# Altium schematic sheet IMX7S_CPU_INTERFACES_1.SchDoc
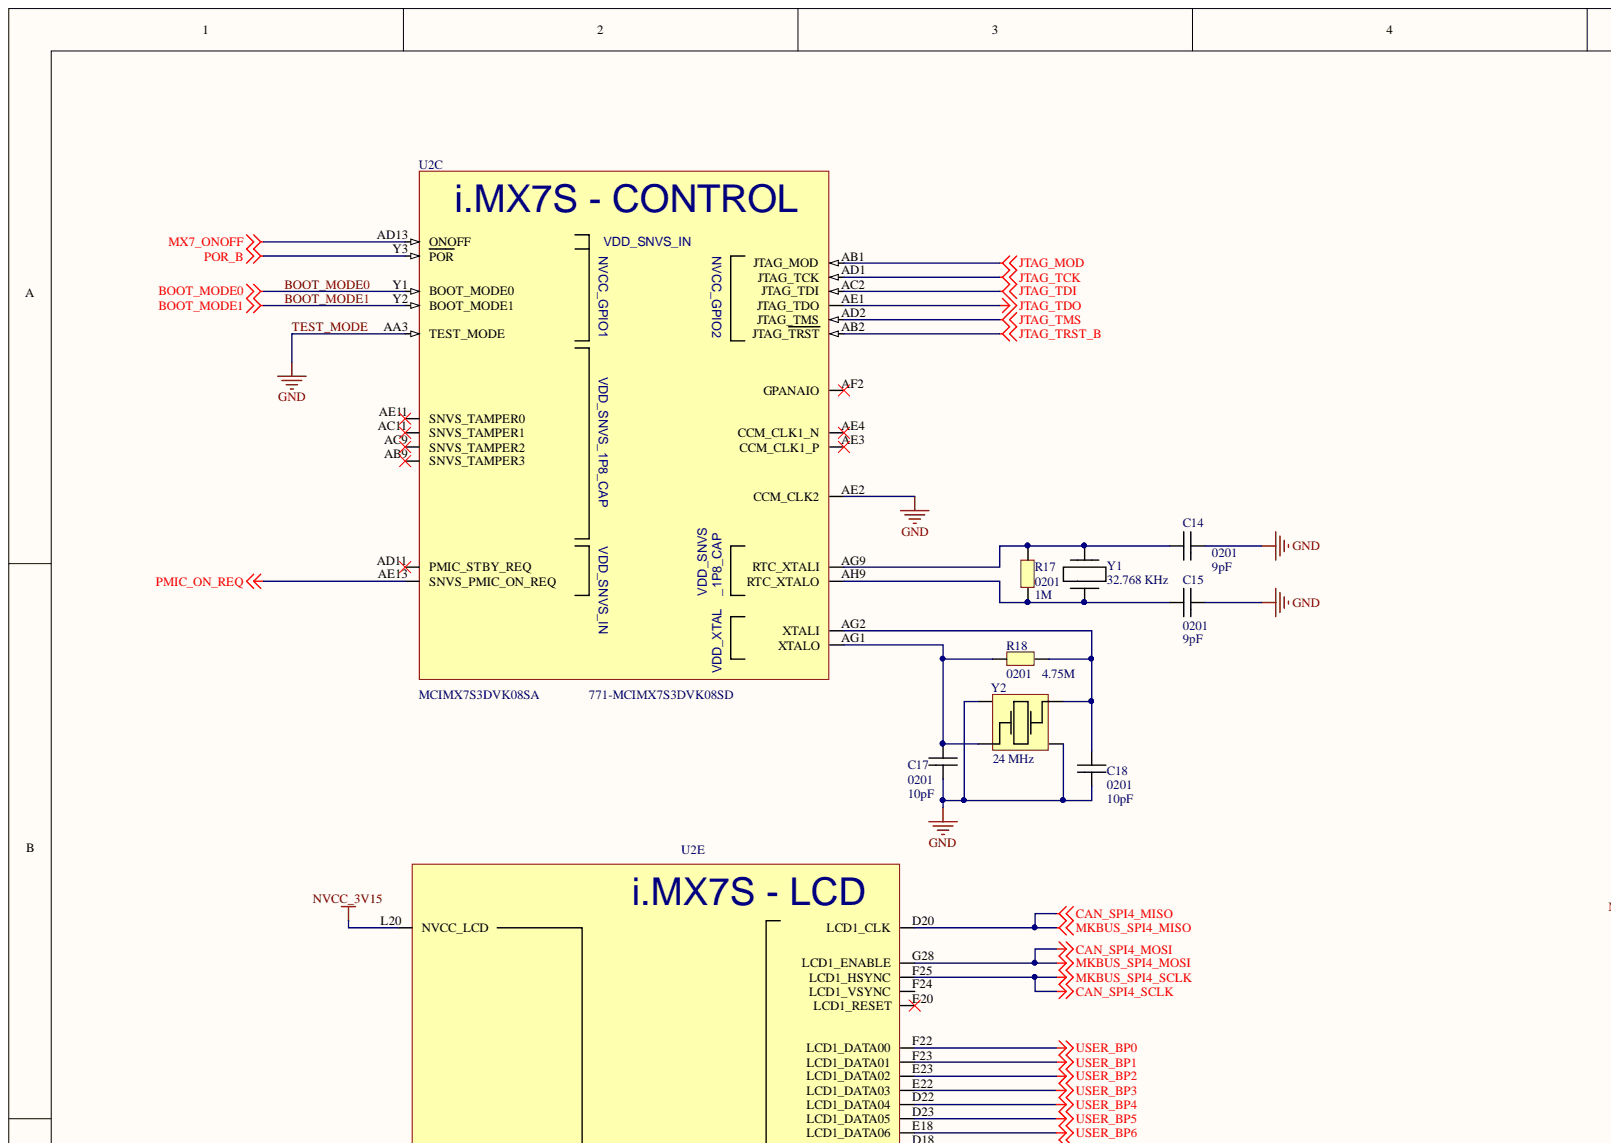
[diagram p0: IMX7S_CPU_INTERFACES_1.SchDoc, top left]
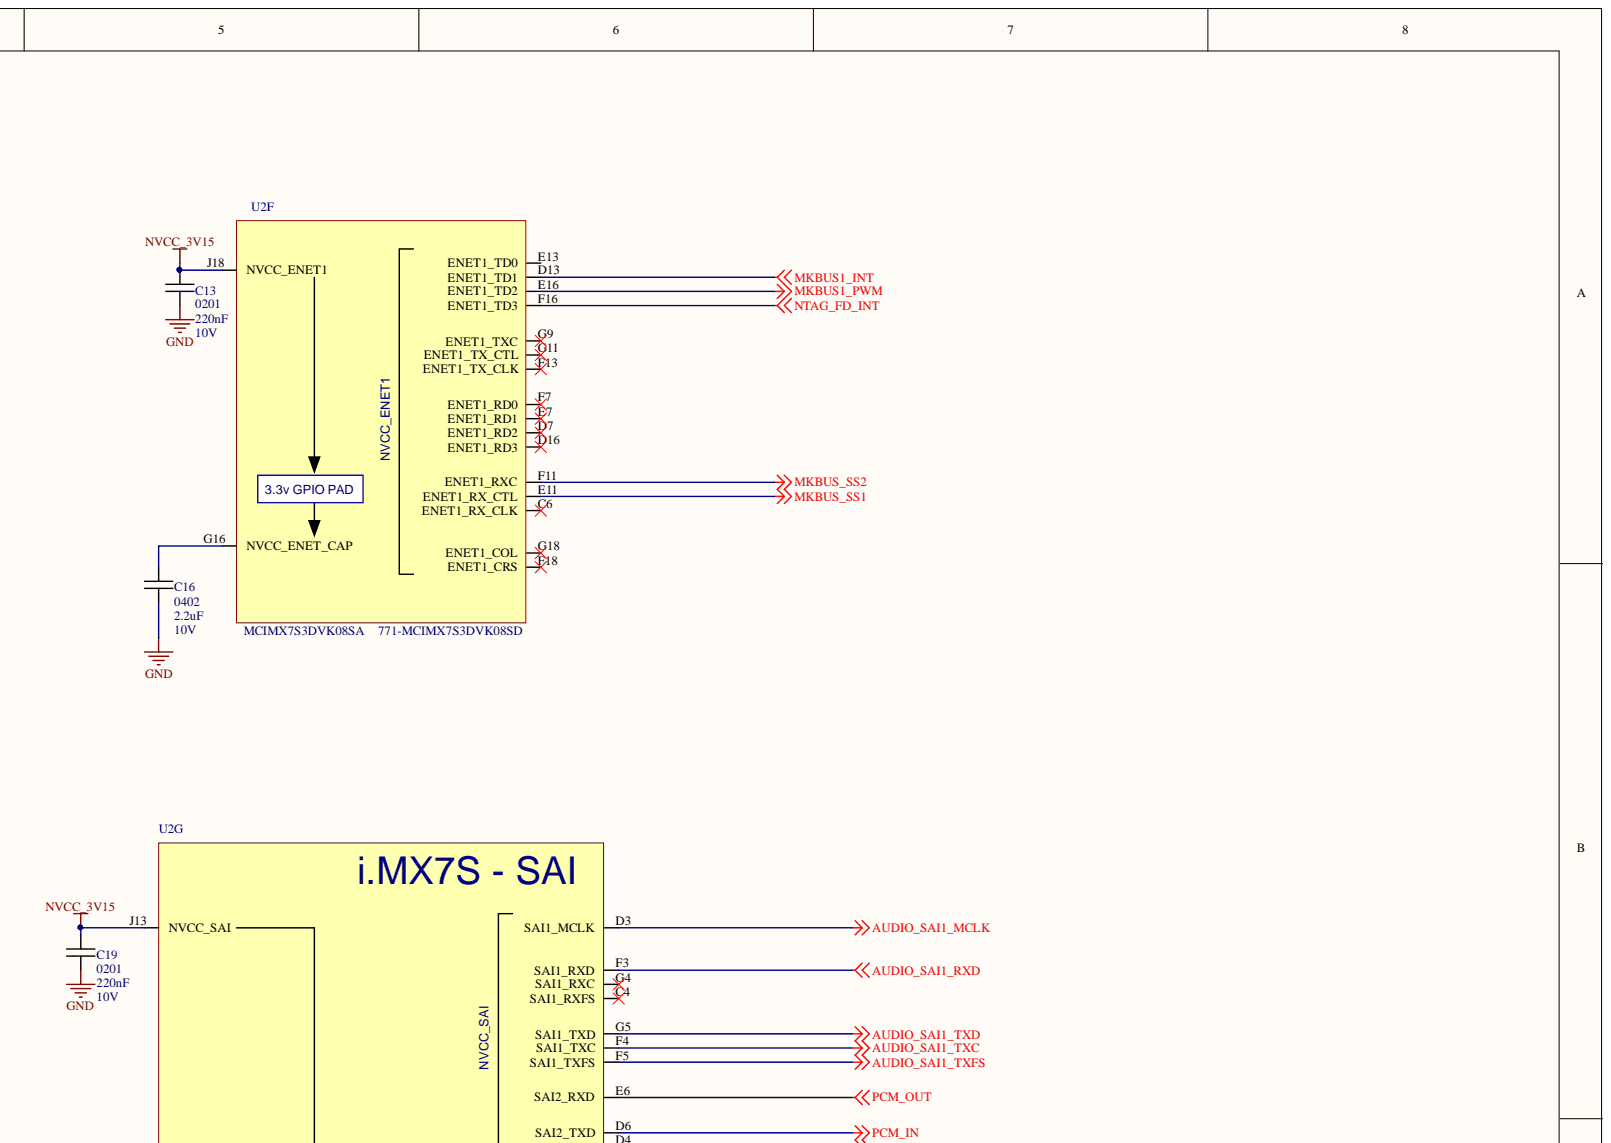
[diagram p1: IMX7S_CPU_INTERFACES_1.SchDoc, top right]
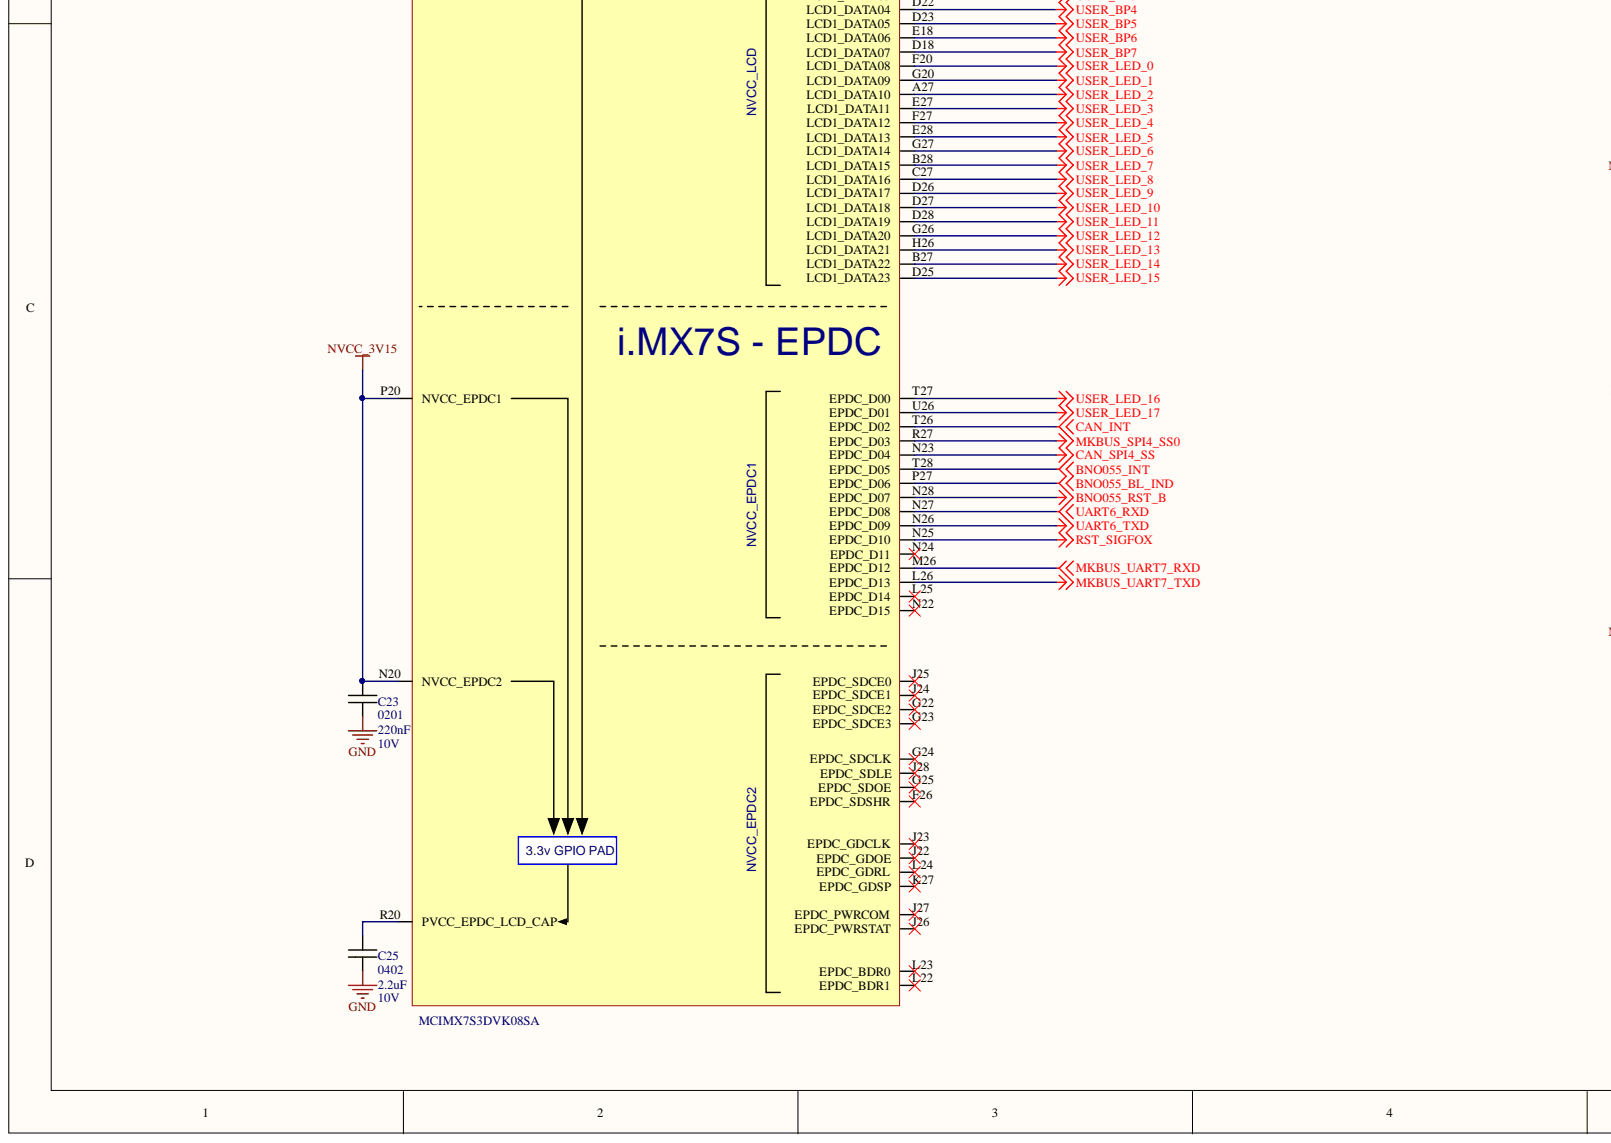
[diagram p2: IMX7S_CPU_INTERFACES_1.SchDoc, bottom left]
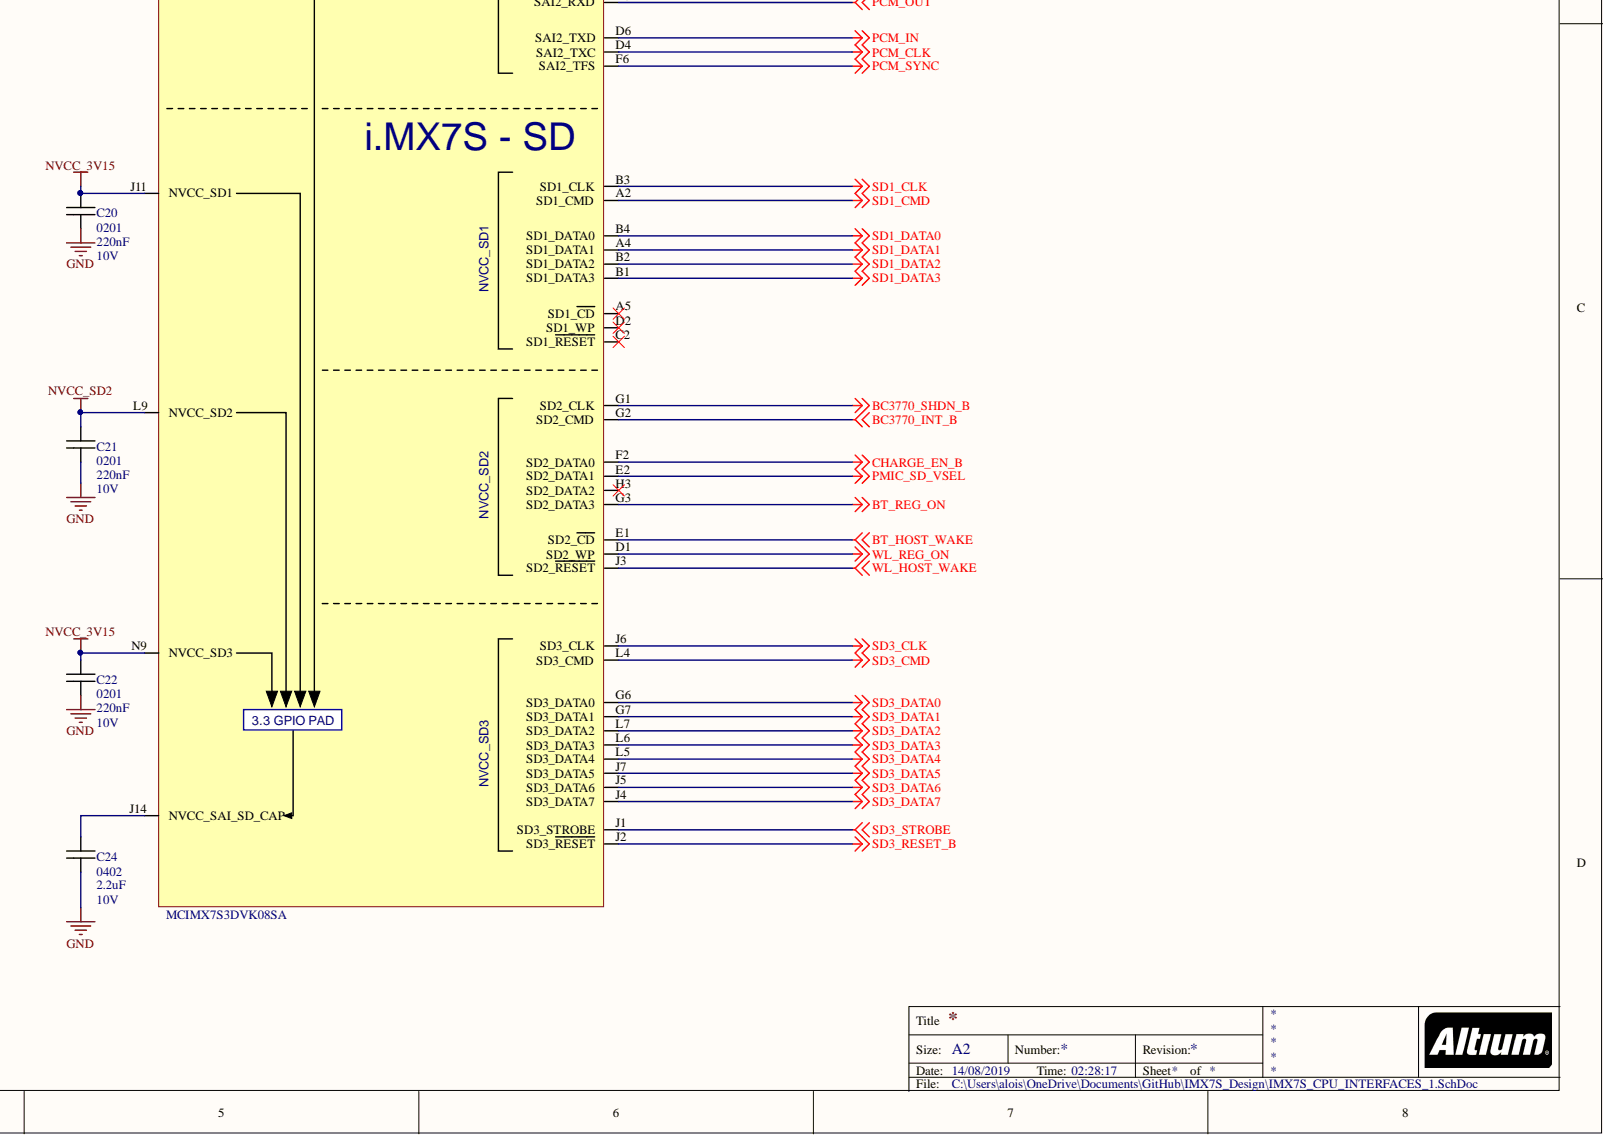
[diagram p3: IMX7S_CPU_INTERFACES_1.SchDoc, bottom right]

## Parts
C13  value 220nF  PACKAGE: 0201  [C0201]  (hidden comment: C0201)
C14  value 9pF  PACKAGE: 0201  [C0201]  (hidden comment: C0201)
C15  value 9pF  PACKAGE: 0201  [C0201]  (hidden comment: C0201)
C16  value 2.2uF  PACKAGE: 0402  VOLTAGE: 10V  [C0402]  (hidden comment: C0402)
C17  value 10pF  PACKAGE: 0201  [C0201]  (hidden comment: C0201)
C18  value 10pF  PACKAGE: 0201  [C0201]  (hidden comment: C0201)
C19  value 220nF  PACKAGE: 0201  VOLTAGE: 10V  [C0201]  (hidden comment: C0201)
C20  value 220nF  PACKAGE: 0201  VOLTAGE: 10V  [C0201]  (hidden comment: C0201)
C21  value 220nF  PACKAGE: 0201  VOLTAGE: 10V  [C0201]  (hidden comment: C0201)
C22  value 220nF  PACKAGE: 0201  VOLTAGE: 10V  [C0201]  (hidden comment: C0201)
C23  value 220nF  PACKAGE: 0201  [C0201]  (hidden comment: C0201)
C24  value 2.2uF  PACKAGE: 0402  VOLTAGE: 10V  [C0402]  (hidden comment: C0402)
C25  value 2.2uF  PACKAGE: 0402  [C0402]  (hidden comment: C0402)
R17  value 1M  PACKAGE: 0201  [R0201]  (hidden comment: R0201)
R18  value 4.75M  PACKAGE: 0201  [R0201]  (hidden comment: R0201)
U2  MCIMX7S3DVK08SA  Supplier Part Number 1: 771-MCIMX7S3DVK08SD  [BGA 488 PIN]  (parts C,E,F,G)
Y1  32.768 KHz  [ABS07]
Y2  24 MHz  [3225 Crystal]

## Nets
AUDIO_SAI1_MCLK: U2.D3(SAI1_MCLK)
AUDIO_SAI1_RXD: U2.F3(SAI1_RXD)
AUDIO_SAI1_TXC: U2.F4(SAI1_TXC)
AUDIO_SAI1_TXD: U2.G5(SAI1_TXD)
AUDIO_SAI1_TXFS: U2.F5(SAI1_TXFS)
BC3770_INT_B: U2.G2(SD2_CMD)
BC3770_SHDN_B: U2.G1(SD2_CLK)
BNO055_BL_IND: U2.P27(EPDC_D06)
BNO055_INT: U2.T28(EPDC_D05)
BNO055_RST_B: U2.N28(EPDC_D07)
BT_HOST_WAKE: U2.E1(SD2_CD)
BT_REG_ON: U2.G3(SD2_DATA3)
CAN_INT: U2.T26(EPDC_D02)
CAN_SPI4_MISO: U2.D20(LCD1_CLK)
CAN_SPI4_MOSI: U2.G28(LCD1_ENABLE)
CAN_SPI4_SCLK: U2.F25(LCD1_HSYNC)
CAN_SPI4_SS: U2.N23(EPDC_D04)
CHARGE_EN_B: U2.F2(SD2_DATA0)
JTAG_MOD: U2.AB1(JTAG_MOD)
JTAG_TCK: U2.AD1(JTAG_TCK)
JTAG_TDI: U2.AC2(JTAG_TDI)
JTAG_TDO: U2.AE1(JTAG_TDO)
JTAG_TMS: U2.AD2(JTAG_TMS)
JTAG_TRST_B: U2.AB2(JTAG_TRST)
MKBUS1_INT: U2.D13(ENET1_TD1)
MKBUS1_PWM: U2.E16(ENET1_TD2)
MKBUS_SPI4_SS0: U2.R27(EPDC_D03)
MKBUS_SS1: U2.E11(ENET1_RX_CTL)
MKBUS_SS2: U2.F11(ENET1_RXC)
MKBUS_UART7_RXD: U2.M26(EPDC_D12)
MKBUS_UART7_TXD: U2.L26(EPDC_D13)
MX7_ONOFF: U2.AD13(ONOFF)
NTAG_FD_INT: U2.F16(ENET1_TD3)
NVCC_3V15: C13.2 C19.2 C20.2 C22.2 C23.2 U2.J11(NVCC_SD1) U2.J13(NVCC_SAI) U2.J18(NVCC_ENET1) U2.L20(NVCC_LCD) U2.N9(NVCC_SD3) U2.N20(NVCC_EPDC2) U2.P20(NVCC_EPDC1)
NVCC_SD2: C21.2 U2.L9(NVCC_SD2)
PCM_CLK: U2.D4(SAI2_TXC)
PCM_IN: U2.D6(SAI2_TXD)
PCM_OUT: U2.E6(SAI2_RXD)
PCM_SYNC: U2.F6(SAI2_TFS)
PMIC_ON_REQ: U2.AE13(SNVS_PMIC_ON_REQ)
PMIC_SD_VSEL: U2.E2(SD2_DATA1)
POR_B: U2.Y3(POR)
RST_SIGFOX: U2.N25(EPDC_D10)
SD1_CLK: U2.B3(SD1_CLK)
SD1_CMD: U2.A2(SD1_CMD)
SD1_DATA0: U2.B4(SD1_DATA0)
SD1_DATA1: U2.A4(SD1_DATA1)
SD1_DATA2: U2.B2(SD1_DATA2)
SD1_DATA3: U2.B1(SD1_DATA3)
SD3_CLK: U2.J6(SD3_CLK)
SD3_CMD: U2.L4(SD3_CMD)
SD3_DATA0: U2.G6(SD3_DATA0)
SD3_DATA1: U2.G7(SD3_DATA1)
SD3_DATA2: U2.L7(SD3_DATA2)
SD3_DATA3: U2.L6(SD3_DATA3)
SD3_DATA4: U2.L5(SD3_DATA4)
SD3_DATA5: U2.J7(SD3_DATA5)
SD3_DATA6: U2.J5(SD3_DATA6)
SD3_DATA7: U2.J4(SD3_DATA7)
SD3_RESET_B: U2.J2(SD3_RESET)
SD3_STROBE: U2.J1(SD3_STROBE)
UART6_RXD: U2.N27(EPDC_D08)
UART6_TXD: U2.N26(EPDC_D09)
USER_BP0: U2.F22(LCD1_DATA00)
USER_BP1: U2.F23(LCD1_DATA01)
USER_BP2: U2.E23(LCD1_DATA02)
USER_BP3: U2.E22(LCD1_DATA03)
USER_BP4: U2.D22(LCD1_DATA04)
USER_BP5: U2.D23(LCD1_DATA05)
USER_BP6: U2.E18(LCD1_DATA06)
USER_BP7: U2.D18(LCD1_DATA07)
USER_LED_0: U2.F20(LCD1_DATA08)
USER_LED_1: U2.G20(LCD1_DATA09)
USER_LED_2: U2.A27(LCD1_DATA10)
USER_LED_3: U2.E27(LCD1_DATA11)
USER_LED_4: U2.F27(LCD1_DATA12)
USER_LED_5: U2.E28(LCD1_DATA13)
USER_LED_6: U2.G27(LCD1_DATA14)
USER_LED_7: U2.B28(LCD1_DATA15)
USER_LED_8: U2.C27(LCD1_DATA16)
USER_LED_9: U2.D26(LCD1_DATA17)
USER_LED_10: U2.D27(LCD1_DATA18)
USER_LED_11: U2.D28(LCD1_DATA19)
USER_LED_12: U2.G26(LCD1_DATA20)
USER_LED_13: U2.H26(LCD1_DATA21)
USER_LED_14: U2.B27(LCD1_DATA22)
USER_LED_15: U2.D25(LCD1_DATA23)
USER_LED_16: U2.T27(EPDC_D00)
USER_LED_17: U2.U26(EPDC_D01)
WL_HOST_WAKE: U2.J3(SD2_RESET)
WL_REG_ON: U2.D1(SD2_WP)
BOOT_MODE0: U2.Y1(BOOT_MODE0)
BOOT_MODE1: U2.Y2(BOOT_MODE1)
TEST_MODE: C13.1 C14.1 C15.1 C16.1 C17.1 C18.1 C19.1 C20.1 C21.1 C22.1 C23.1 C24.1 C25.1 U2.AA3(TEST_MODE) U2.AE2(CCM_CLK2) Y2.3 Y2.5
NetC14_2: C14.2 R17.2 U2.AG9(RTC_XTALI) Y1.2
NetC15_2: C15.2 R17.1 U2.AH9(RTC_XTALO) Y1.1
NetC16_2: C16.2 U2.G16(NVCC_ENET_CAP)
NetC17_2: C17.2 R18.2 U2.AG1(XTALO) Y2.2
NetC18_2: C18.2 R18.1 U2.AG2(XTALI) Y2.4
NetC24_2: C24.2 U2.J14(NVCC_SAI_SD_CAP)
NetC25_2: C25.2 U2.R20(PVCC_EPDC_LCD_CAP)

Not connected: U2 A5(SD1_CD) AB9(SNVS_TAMPER3) AC9(SNVS_TAMPER2) AC11(SNVS_TAMPER1) AD11(PMIC_STBY_REQ) AE3(CCM_CLK1_P) AE4(CCM_CLK1_N) AE11(SNVS_TAMPER0) AF2(GPANAIO) C2(SD1_RESET) C4(SAI1_RXFS) C6(ENET1_RX_CLK) D2(SD1_WP) D7(ENET1_RD2) D16(ENET1_RD3) E7(ENET1_RD1) E13(ENET1_TD0) E20(LCD1_RESET) F7(ENET1_RD0) F13(ENET1_TX_CLK) F18(ENET1_CRS) F24(LCD1_VSYNC) F26(EPDC_SDSHR) G4(SAI1_RXC) G9(ENET1_TXC) G11(ENET1_TX_CTL) G18(ENET1_COL) G22(EPDC_SDCE2) G23(EPDC_SDCE3) G24(EPDC_SDCLK) G25(EPDC_SDOE) H3(SD2_DATA2) J22(EPDC_GDOE) J23(EPDC_GDCLK) J24(EPDC_SDCE1) J25(EPDC_SDCE0) J26(EPDC_PWRSTAT) J27(EPDC_PWRCOM) J28(EPDC_SDLE) K27(EPDC_GDSP) L22(EPDC_BDR1) L23(EPDC_BDR0) L24(EPDC_GDRL) L25(EPDC_D14) N22(EPDC_D15) N24(EPDC_D11)

## Library data of the parts
C13 = C0201: VALUE: 220nF; VOLTAGE: 10V; Component Kind: Standard; Library Name: Schlib_IMX7.SchLib
C14 C15 C17 C18 = C0201: VOLTAGE: 0V; Component Kind: Standard; Library Name: Schlib_IMX7.SchLib
C23 = C0201: VOLTAGE: 10V; Component Kind: Standard; Library Name: Schlib_IMX7.SchLib
C25 = C0402: VOLTAGE: 10V; Component Kind: Standard; Library Name: Schlib_IMX7.SchLib
R17 R18 = R0201: VOLTAGE: 0V; Component Kind: Standard; Library Name: Schlib_IMX7.SchLib
U2 = MCIMX7S3DVK08SD: Component Kind: Standard; Library Name: Schlib_IMX7.SchLib; Supplier 1: Mouser
Y1 = Quartz 32.768: Component Kind: Standard; Library Name: Schlib_IMX7.SchLib; Supplier 1: Farnell; Supplier Part Number 1: 2819305
Y2 = Quartz 24M: Component Kind: Standard; Library Name: Schlib_IMX7.SchLib; Supplier 1: Farnell; Supplier Part Number 1: 2853961
C16 C24 = C0402: Component Kind: Standard; Library Name: Schlib_IMX7.SchLib
C19-C22 = C0201: Component Kind: Standard; Library Name: Schlib_IMX7.SchLib
